# Altium schematic sheet External-Lower.SchDoc
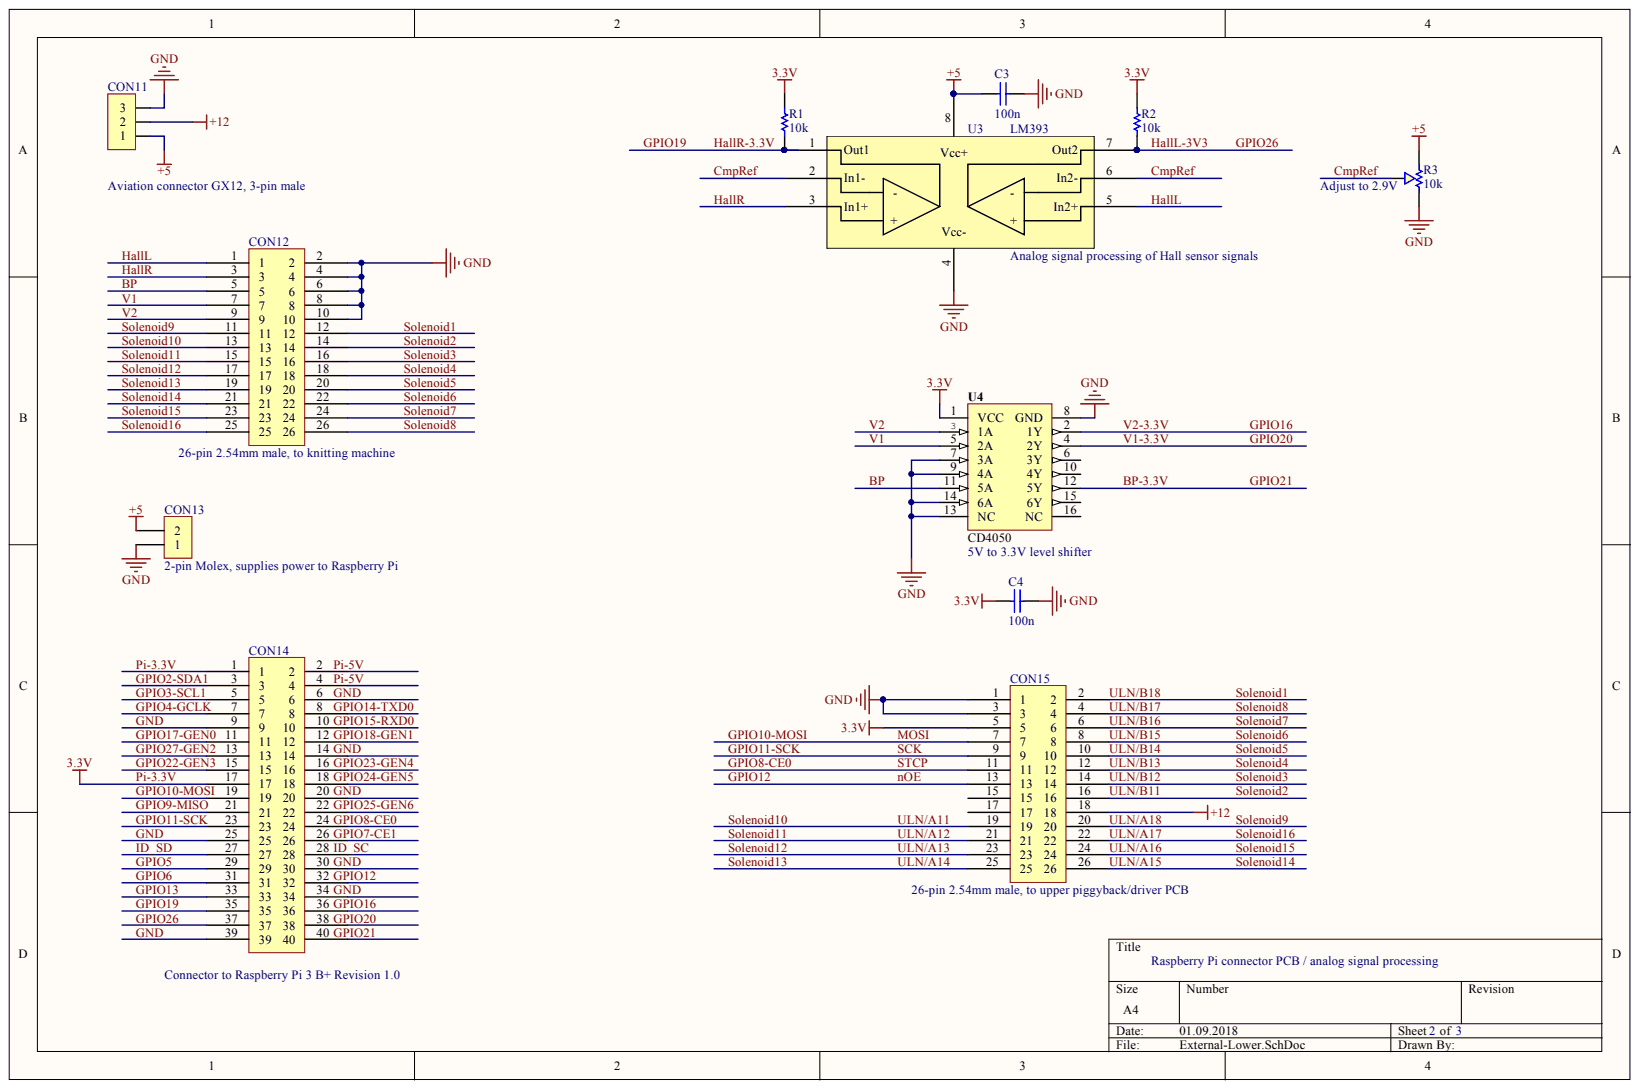
[diagram p0: External-Lower.SchDoc]

## Parts
C3  100n  [PCB-xlqz8i8lofgldnfjb9dw-1]
C4  100n  [PCB-xlqz8i8lofgldnfjb9dw-1]
CON11  Aviation connector GX12, 3-pin male  [PCBComponent_1 - duplicate]
CON12  26-pin 2.54mm male, to knitting machine  [PCB-anyg1stvhu39ted5u9rh-1]
CON13  2-pin Molex, supplies power to Raspberry Pi  [PCB-leb05u44dbjj2etntkke-1]
CON14  Connector to Raspberry Pi 3 B+ Revision 1.0  [PCB-o2ctyyobwag5o2q1yp8n-1]
CON15  26-pin 2.54mm male, to upper piggyback/driver PCB  [PCB-anyg1stvhu39ted5u9rh-1]
R1  10k  [RESC2012X07L]
R2  10k  [RESC2012X07L]
R3  10k  [PCBComponent_1]
U3  LM393  [SOIC127P600X175-8N]
U4  CD4050  [PCB-syyil621vw9lt1l12wwd-1]

## Nets
+5: C3.1 CON11.1 CON13.2 R3.3 U3.8
+12: CON11.2 CON15.18
BP: CON12.5 U4.11(5A)
BP-3.3V: U4.12(5Y)
CMPREF: R3.2 U3.2(In1-) U3.6(In2-)
GND: C3.2 C4.2 CON11.3 CON12.2 CON12.4 CON12.6 CON12.8 CON12.10 CON13.1 CON14.9 CON14.25 CON14.39 CON15.1 CON15.3 R3.1 U3.4 U4.7(3A) U4.8(GND) U4.9(4A) U4.13(NC) U4.14(6A)
GPIO2-SDA1: CON14.3
GPIO3-SCL1: CON14.5
GPIO4-GCLK: CON14.7
GPIO5: CON14.29
GPIO6: CON14.31
GPIO8-CE0: CON15.11
GPIO9-MISO: CON14.21
GPIO10-MOSI: CON14.19 CON15.7
GPIO11-SCK: CON14.23 CON15.9
GPIO12: CON15.13
GPIO13: CON14.33
GPIO16: U4.2(1Y)
GPIO17-GEN0: CON14.11
GPIO19: CON14.35 R1.1 U3.1(Out1)
GPIO20: U4.4(2Y)
GPIO22-GEN3: CON14.15
GPIO26: CON14.37 R2.1 U3.7(Out2)
GPIO27-GEN2: CON14.13
HALLL: CON12.1 U3.5(In2+)
HALLR: CON12.3 U3.3(In1+)
ID_SD: CON14.27
PI-3.3V: C4.1 CON14.1 CON14.17 CON15.5 R1.2 R2.2 U4.1(VCC)
SOLENOID1: CON12.12 CON15.2
SOLENOID2: CON12.14 CON15.16
SOLENOID3: CON12.16 CON15.14
SOLENOID4: CON12.18 CON15.12
SOLENOID5: CON12.20 CON15.10
SOLENOID6: CON12.22 CON15.8
SOLENOID7: CON12.24 CON15.6
SOLENOID8: CON12.26 CON15.4
SOLENOID9: CON12.11 CON15.20
SOLENOID10: CON12.13 CON15.19
SOLENOID11: CON12.15 CON15.21
SOLENOID12: CON12.17 CON15.23
SOLENOID13: CON12.19 CON15.25
SOLENOID14: CON12.21 CON15.26
SOLENOID15: CON12.23 CON15.24
SOLENOID16: CON12.25 CON15.22
V1: CON12.7 U4.5(2A)
V2: CON12.9 U4.3(1A)
GPIO7-CE1:
GPIO14-TXD0:
GPIO15-RXD0:
GPIO18-GEN1:
GPIO23-GEN4:
GPIO24-GEN5:
GPIO25-GEN6:
ID_SC:
PI-5V:

Not connected: CON14 2 4 6 8 10 12 14 16 18 20 22 24 26 28 30 32 34 36 38 40; CON15 15 17; U4 6(3Y) 10(4Y) 15(6Y) 16(NC)

## Library data of the parts
C3 C4 = K222K10X7RF5UH5: Cap Ceramic 0.0022uF 50V X7R 10% Radial 5mm 125°C Ammo Pack; Capacitance: 2.20 nF; Voltage Rating (DC): 50.0 V; Capacitance Tolerance: ±10%; Component Kind: Standard; Dielectric Characteristic: X7R; Library Name: Octopart library; Mounting Style: Through Hole; OctopartID: acaf652899037368; Packaging: Cut Tape (CT)
CON11 = CMP-c3e20a8fd0141c25-2: 2.54mm (.100") Pitch C-Grid III Header, Single Row, Vertical, 3 Circuits, Tin (Sn) Plating; Component Kind: Standard; Library Name: CommunityVault
CON12 CON15 = 5499922-6: Pin strip + ejector (long) Contact spacing: 2.54 mm Total number of pins: 26 TE Connectivity 1 pc(s); Current Rating: 1.00 A; ELV Compliant: Compliant; Lead-Free Status: Lead Free; Mounting Angle: 180 °; Number of Mating Cycles: 50; Number of Positions: 26; Color: Black; Component Kind: Standard; Contact Plating: Gold, Tin; Flammability Rating: UL 94 V-0; Gender: Male; Housing Color: Black; Housing Material: Thermoplastic; Library Name: Octopart library; Manufacturer: TE Connectivity; Manufacturer Part Number: 5499922-6; Mounting Style: Through Hole, Vertical; Number of Rows: 2; OctopartID: ed6f263ec2da32a8; Octopart URL: http://octopart.com/5499922-6-te+connectivity+%2F+amp-39520979; Orientation: Vertical; Packaging: Tray; Pin Pitch: 2.54 mm; RoHS: Compliant; Size-Height: 13.9 mm; Size-Length: 52.3 mm; Size-Width: 8.53 mm
CON13 = 22-28-4022: KK® 254 Breakaway Header, Vertical, 2 Circuits, Tin (Sn) Plating, Mating Pin Length 7.49mm; Number of Positions: 2; Component Kind: Standard; Housing Color: Black; Library Name: Octopart library; RoHS: Compliant
CON14 = M50-3602042: Conn Unshrouded Header HDR 40 POS 1.27mm Solder ST SMD Tray; Insulation Resistance: 5.00 GΩ; Component Kind: Standard; Contact Material: Copper Alloy; Contact Plating: Gold; Library Name: Octopart library; Mounting Style: Surface Mount, Vertical; Number of Rows: 2; Pin Pitch: 1.27 mm; RoHS: Compliant
R1 R2 = RP73PF2A12K1BTDF: Surface Mount Thin Film Resistor, RP73 Series, 12.1 kohm, 250 mW, - 0.1%, 150 V; Power Rating: 250 mW; Resistance: 12.1 kΩ; Voltage Rating (DC): 150 V; Case/Package: 0805, 2012; Component Kind: Standard; Library Name: Octopart library; Lifecycle Status: Not Listed by Manufacturer; Manufacturer Part Number: RP73PF2A12K1BTDF; Mounting Style: Surface Mount; OctopartID: 56ed9d52b0ae892a; Octopart URL: http://octopart.com/rp73pf2a12k1btdf-te+connectivity-45466405; Packaging: Cut Tape (CT); REACH SVHC Compliance: No SVHC; Resistance Tolerance: ±0.1%; RoHS: Compliant
R3 = 3310Y-001-103L: Res Conductive Plastic POT 10K Ohm 20% 1/4W 1(Elec)/1(Mech)Turn 3.18mm Pin Panel Mount/Through Hole; Resistance: 10.0 kΩ; Voltage Rating (AC): 200 V; Component Kind: Standard; Library Name: Octopart library; Manufacturer Part Number: 3310Y-001-103L; Mounting Style: Through Hole, Vertical; OctopartID: 2056e954d6efff91; Octopart URL: http://octopart.com/3310y-001-103l-bourns-578527; REACH SVHC Compliance: No SVHC; Resistance Tolerance: ±20%; RoHS: Compliant; Size-Height: 4.06 mm; Size-Length: 9.52 mm; Size-Width: 9.52 mm
U3 = LM393DT: Linear IC - Comparator STMicroelectronics LM393DT Multi-purpose CMOS, MOS, Open collector, TTL SO 8; Lead-Free Status: Lead Free; Number of Channels: 2; Output Current: 100 pA; Supply Current: 1.00 mA; Component Kind: Standard; Library Name: Octopart library; Lifecycle Status: Active; Manufacturer Part Number: LM393DT; Number of Pins: 8; OctopartID: a335686477ed7cf2; Octopart URL: http://octopart.com/lm393dt-stmicroelectronics-39580094; Packaging: Cut Tape (CT), Tape & Reel (TR); REACH SVHC Compliance: No SVHC
U4 = CD4050BE: CMOS Hex Non-Inverting Buffer/Converter 16-PDIP -55 to 125; Lead-Free Status: Lead Free; Number of Bits: 6; Output Current Drive: -609 µA; Propagation Delay Max (tpd): 140 ns; Supply Voltage (DC): 3.00 V to 18.0 V; Component Kind: Standard; Library Name: Octopart library; Manufacturer Part Number: CD4050BE; Number of Circuits: 6; Number of Outputs: 6; Number of Pins: 16; OctopartID: 79e4b0ee44e2a623; Octopart URL: http://octopart.com/cd4050be-texas+instruments-6240; Packaging: Tube; RoHS: Compliant
